# Altium schematic sheet 02-RCB_Header.SchDoc(02-RCB_Header)
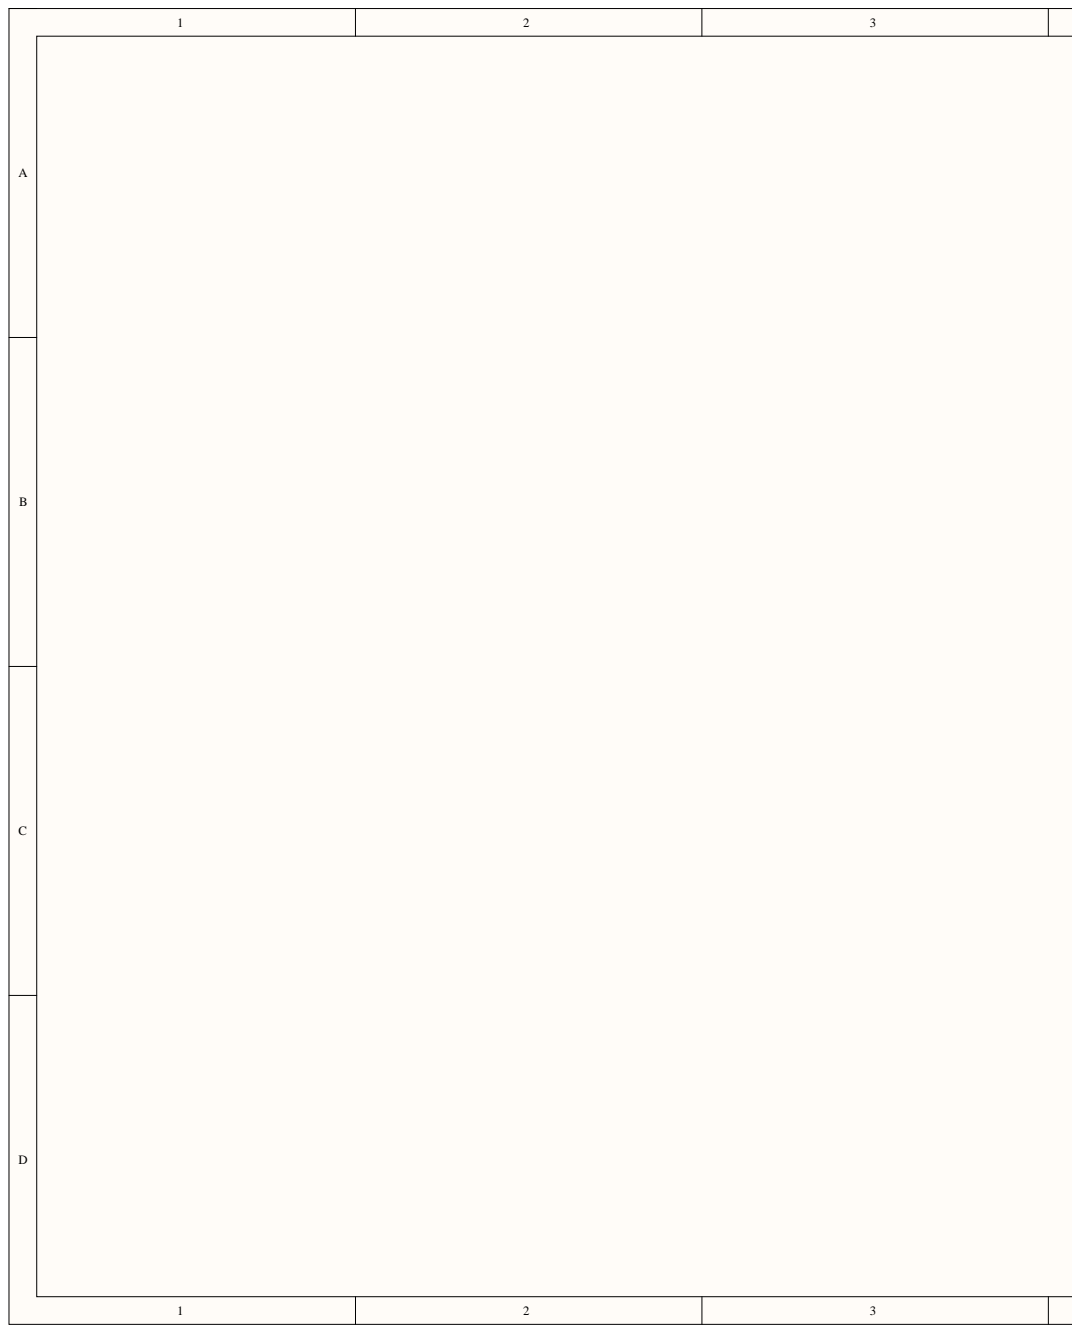
[diagram p0: 02-RCB_Header.SchDoc, left]
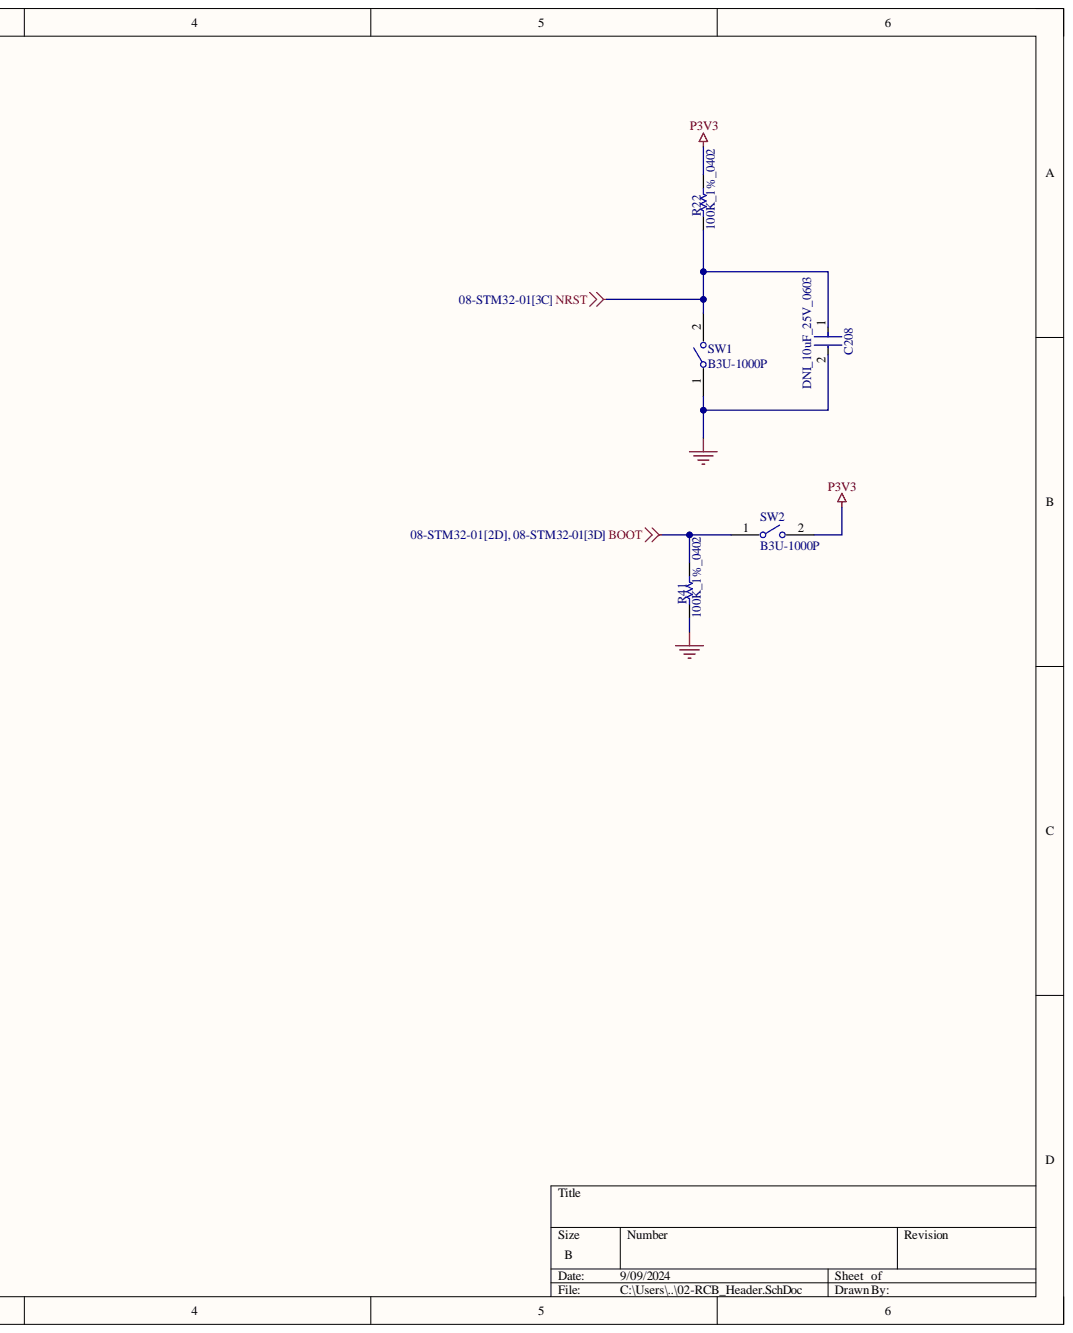
[diagram p1: 02-RCB_Header.SchDoc, right]

## Parts
C208  DNI_10uF_25V_0603  [FP-CL10-IPC_C]  (no BOM)
R22  100K_1%_0402  [RESC1005X40X25ML05T10]
R41  100K_1%_0402  [RESC1005X40X25ML05T10]
SW1  B3U-1000P  [FP-B3U-1000P-MFG]
SW2  B3U-1000P  [FP-B3U-1000P-MFG]

## Nets
BOOT: R41.2 SW2.1
GND: C208.2 R41.1 SW1.1
NRST: C208.1 R22.1 SW1.2
P3V3: R22.2 SW2.2

## Library data of the parts
C208 = CMP-13271-004224-1: Cap Ceramic 10uF 25V X5R ±20% Pad SMD 0603 +85°C T/R; Capacitance: 10uF; Case Code (Imperial): 0603; Case Code (Metric): 1608; Case/Package: 0603; Contact Plating: Tin; Dielectric: X5R; Lead Free: Lead Free; Length: 0.063inch; Library Name: Altium Content Vault; Max Operating Temperature: 85°C; Min Operating Temperature: -55°C; Mount: Surface Mount; Package Quantity: 1; Packaging: Tape and Reel; Termination: Wraparound; Thickness: 0.035inch; Tolerance: 20%; Voltage Rating: 25V; Width: 0.031inch
R22 R41 = CMP-2002-07852-1: Manufacturer: Yageo; Part Number: RC0402FR-13100KL; Component Kind: Standard; Library Name: Altium Content Vault
SW1 SW2 = CMP-2100-03586-2: SWITCH TACTILE SPST-NO 0.05A 12V; Actuator Length: 0.4mm; Actuator Type: Standard; Contact Current Rating: 50mA; Contact Resistance: 100mR; Electrical Life: 200000Cycles; Illumination Type: Non-Illuminated; Operating Force: 1.5N; Ratings: Dust Proof; Switch Function: Off-Mom; Switch Travel: 0.15mm; Throw Configuration: SPST; Component Kind: Standard; Contact Material: Silver; Current Rating: 50mA; Depth: 2.5mm; Height: 1.2mm; Insulation Resistance: 100MR; Lead Free: Lead Free; Length: 4mm; Library Name: Altium Content Vault; Max Current Rating: 50mA; Max Operating Temperature: 70°C; Max Voltage Rating (DC): 12V; Mechanical Life: 200000Cycles; Min Operating Temperature: -25°C; Mount: Surface Mount; Orientation: Straight; Package Quantity: 1; Packaging: Tape and Reel; Termination: SMD/SMT; Voltage Rating (DC): 12V; Weight: 0.000776oz; Width: 3mm
